# Altium schematic sheet Schematic("All Documents",Physical)
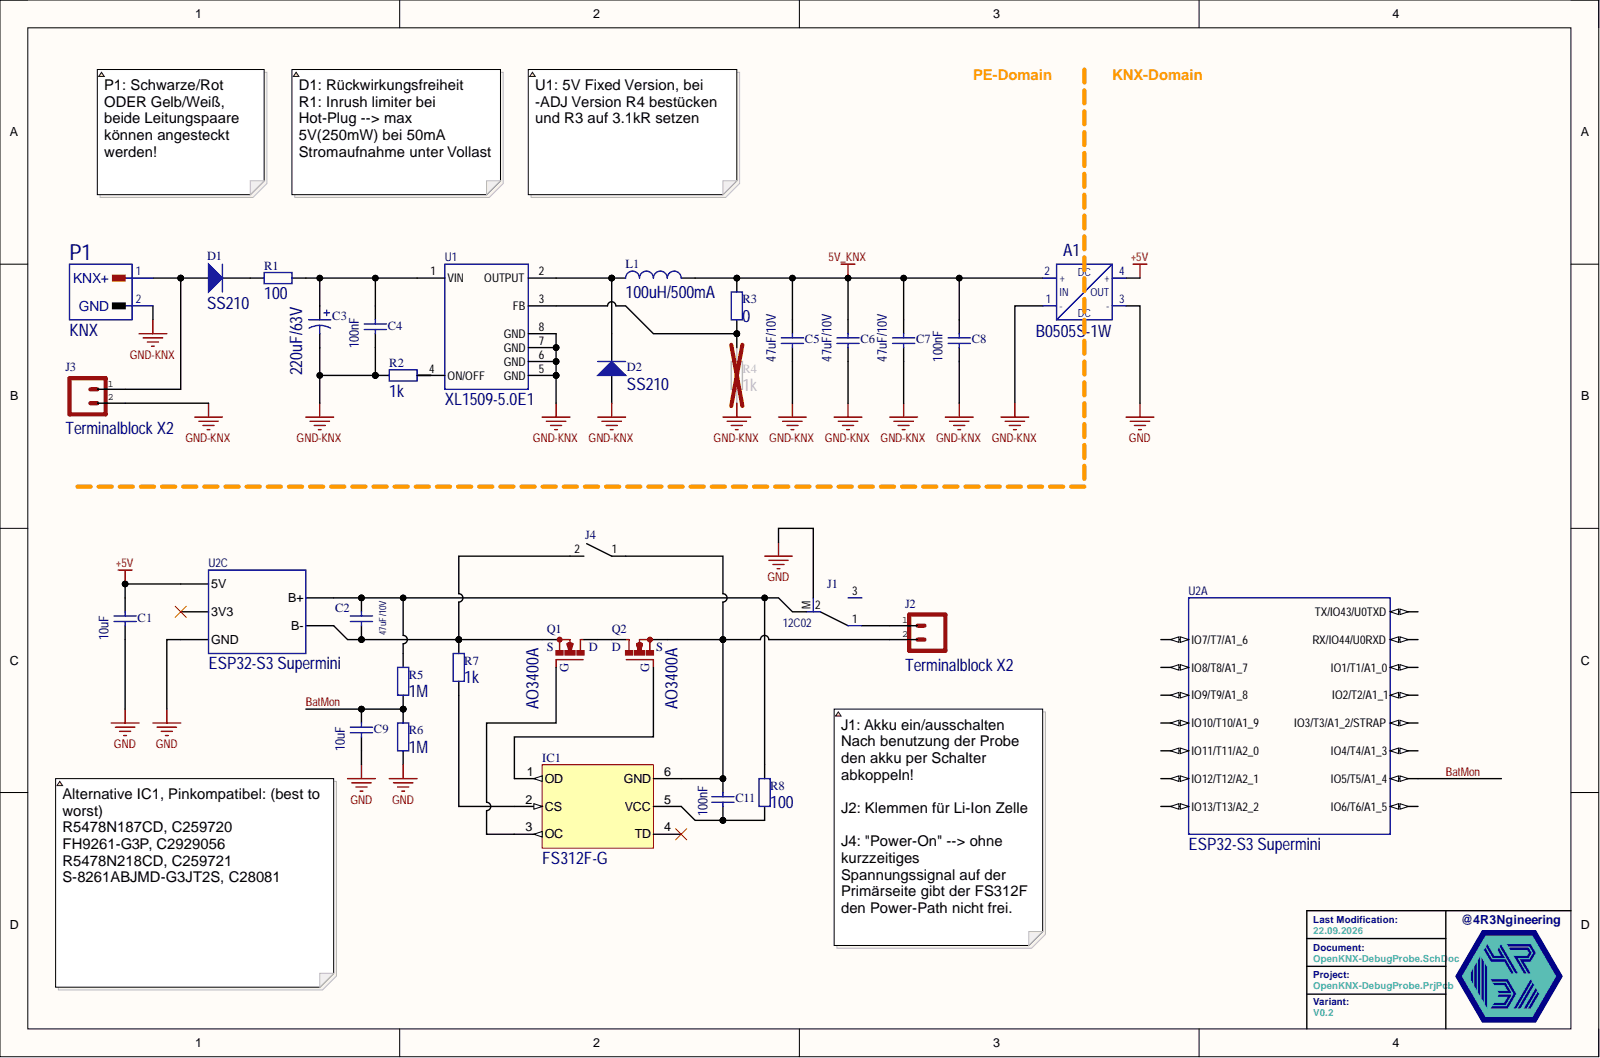
[diagram p0: Schematic("All Documents",Physical)]

## Parts
A1  B0505S-1W  [B05_S-1WR3]
C1  10uF  [C0603]
C2  47uF/10V  [C1206]
C3  220uF/63V  [CAP-SMD_BD12.5-L13.0-W13.0-LS14.4-FD]
C4  100nF  [C0603]
C5  47uF/10V  [C1206]
C6  47uF/10V  [C1206]
C7  47uF/10V  [C1206]
C8  100nF  [C0603]
C9  10uF  [C0603]
C11  100nF  [C0603]
D1  SS210  [DO-214]
D2  SS210  [DO-214]
IC1  FS312F-G  [SOT23-6]
J1  12C02  [SW-SMD_12C02]
J2  Terminalblock X2  [Terminalblock_X2_3.5mm]
J3  Terminalblock X2  [Terminalblock_X2_5mm]
J4  Tactile Switch  [TS-1088-AR02016]  (value not drawn)
L1  100uH/500mA  [5x5mm]
P1  KNX  [Wago 243-211 / KNX B/R]
Q1  AO3400A  [SOT23 Normal]
Q2  AO3400A  [SOT23 Normal]
R1  100  [R2512]
R2  1k  [R0603]
R3  0  [R0603]
R4  1k  [R0603]
R5  1M  [R1206]
R6  1M  [R1206]
R7  1k  [R0603]
R8  100  [R0603]
U1  XL1509-5.0E1  [SOP8]
U2  ESP32-S3 Supermini  [ESP32-S3 Supermini]  (parts A,C)

## Nets
5V_KNX: A1.2(+) A1.4(+) C1.2 C5.2 C6.2 C7.2 C8.2 L1.2 R3.2 U2.5V
GND: A1.3(-) C1.1 C9.1 J1.M R6.1 U2.GND
GND-KNX: A1.1(-) C3.1 C4.1 C5.1 C6.1 C7.1 C8.1 D2.2 J3.2 P1.2 R2.1 R4.1 U1.5(GND) U1.6(GND) U1.7(GND) U1.8(GND)
BatMon: C9.2 R5.1 R6.2 U2.5(IO5/T5/A1_4)
NetC2_1: C2.1 J4.2 Q1.3 R7.2 U2.B-
NetC2_2: C2.2 J1.2 R5.2 R8.1 U2.B+
NetC3_2: C3.2 C4.2 R1.2 U1.1(VIN)
NetC11_1: C11.1 IC1.5(VCC) R8.2
NetC11_2: C11.2 IC1.6(GND) J2.2 J4.1 Q2.3
NetD1_1: D1.1 R1.1
NetD1_2: D1.2 J3.1 P1.1
NetD2_1: D2.1 L1.1 U1.2(OUTPUT)
NetIC1_1: IC1.1(OD) Q2.1
NetIC1_2: IC1.2(CS) R7.1
NetIC1_3: IC1.3(OC) Q1.1
NetIC1_4: IC1.4(TD)
NetJ1_1: J1.1 J2.1
NetJ1_3: J1.3
NetQ1_2: Q1.2 Q2.2
NetR2_2: R2.2 U1.4(ON/OFF)
NetR3_1: R3.1 R4.2 U1.3(FB)
NetU2_1: U2.1(IO1/T1/A1_0)
NetU2_2: U2.2(IO2/T2/A1_1)
NetU2_3: U2.3(IO3/T3/A1_2/STRAP)
NetU2_3V3: U2.3V3
NetU2_4: U2.4(IO4/T4/A1_3)
NetU2_6: U2.6(IO6/T6/A1_5)
NetU2_7: U2.7(IO7/T7/A1_6)
NetU2_8: U2.8(IO8/T8/A1_7)
NetU2_9: U2.9(IO9/T9/A1_8)
NetU2_10: U2.10(IO10/T10/A1_9)
NetU2_11: U2.11(IO11/T11/A2_0)
NetU2_12: U2.12(IO12/T12/A2_1)
NetU2_13: U2.13(IO13/T13/A2_2)
NetU2_RX: U2.RX(RX/IO44/U0RXD)
NetU2_TX: U2.TX(TX/IO43/U0TXD)

## Library data of the parts
A1 = DCDC-Converter BxxyyS-1W: Component Kind: Standard; Library Name: cadLib.IntLib
C1 C2 C4-C9 C11 = Cap: Capacitor; Component Kind: Standard; Signal Integrity: Cap; Simulation: CAP; Library Name: cadLib.IntLib
C3 = Cap Pol: Polarized Capacitor (Axial); Component Kind: Standard; LatestRevisionDate: 17-Jul-2002; LatestRevisionNote: Re-released for DXP Platform.; PackageDescription: Polarized Capacitor; 2 Leads; PackageReference: POLAR0.8; Published: 8-Jun-2000; Signal Integrity: Cap Pol; Simulation: CAP; Library Name: cadLib.IntLib; Publisher: Altium Limited
D1 D2 = Diode: PCB_Layout: Complies with manufacturer's recommendation.; Component Kind: Standard; ComponentLink1Description: Manufacturer Link; ComponentLink1URL: http://www.osram-os.com/; ComponentLink2Description: Datasheet; ComponentLink2URL: http://catalog.osram-os.com/catalogue/catalogue.do;jsessionid=AD571800CED0208623E7FAFDF556DB1C?act=downloadFile&favOid=020000030001e106000200b6; DatasheetVersion: Mar-2007; Description: Diode; PackageDescription: White LED; 2 Reverse Gullwing Leads, Body 1.9 x 0.875mm (Dia.xH); PackageReference: LWP4SG; PackageVersion: Mar-2007; Published: 14-Mar-2008; Library Name: cadLib.IntLib; Publisher: Altium Limited
IC1 = DW01/FS312F: Battery Protection IC; Component Kind: Standard; Library Name: cadLib_IC.IntLib
J1 = Switch_Changeover: Switch_THT; Component Kind: Standard; Library Name: cadLib.IntLib
J4 = Tact_SW_2Pins: Component Kind: Standard; Description: Tactile Switch; LatestRevisionDate: 17-Jul-2002; LatestRevisionNote: Re-released for DXP Platform.; PackageDescription: Axial Device, Thru-Hole; 2 Leads; 0.4 in Pin Spacing; PackageReference: AXIAL-0.4; Published: 8-Jun-2000; Library Name: cadLib.IntLib; Publisher: Altium Limited
L1 = Inductor: Code_IEC: 1005; Code_JEITA: 0402; Component Kind: Standard; Description: Inductor; LatestRevisionDate: 29-May-2009; LatestRevisionNote: IPC-7351 Footprint Added.; PackageDescription: Chip Inductor; PackageReference: 0402-A; Published: 8-Jun-2000; Signal Integrity: Inductor; Simulation: INDUCTOR; Library Name: cadLib.IntLib; Publisher: Altium Limited
P1 = Wago 243-211 / KNX Klemme: Wago 243-211 / KNX Klemme Schwarz/Rot; Component Kind: Standard; Library Name: cadLib_connectors.IntLib
Q1 Q2 = AO3400A: 30V N-Channel MOSFET; Component Kind: Standard; ComponentLink1Description: Manufacturer Link; ComponentLink1URL: http://www.irf.com/; ComponentLink2Description: Datasheet; ComponentLink2URL: http://www.irf.com/product-info/datasheets/data/irlr024n.pdf; Library Name: BJT_MOSFET.IntLib
R1-R8 = Res: Resistor; Component Kind: Standard; Signal Integrity: Res; Simulation: RESISTOR; Library Name: cadLib.IntLib
U2 = ESP32-S3 Supermini: ESP32-S3 SuperMini (nologo.tech). 18 castellated edge pins, 20 bottom-side test pads, 2 battery pads. Board 18.00 x 23.50 mm.; Component Kind: Standard; Library Name: cadLib.IntLib
J2 J3 = Terminalblock X2: Component Kind: Standard; Library Name: cadLib_connectors.IntLib
U1 = XL1509-5.0E1: Component Kind: Standard; Description: XL1509-5.0E1; Library Name: cadLib_IC.IntLib
